# Altium schematic sheet 6_SX1262.SchDoc(6_SX1262)
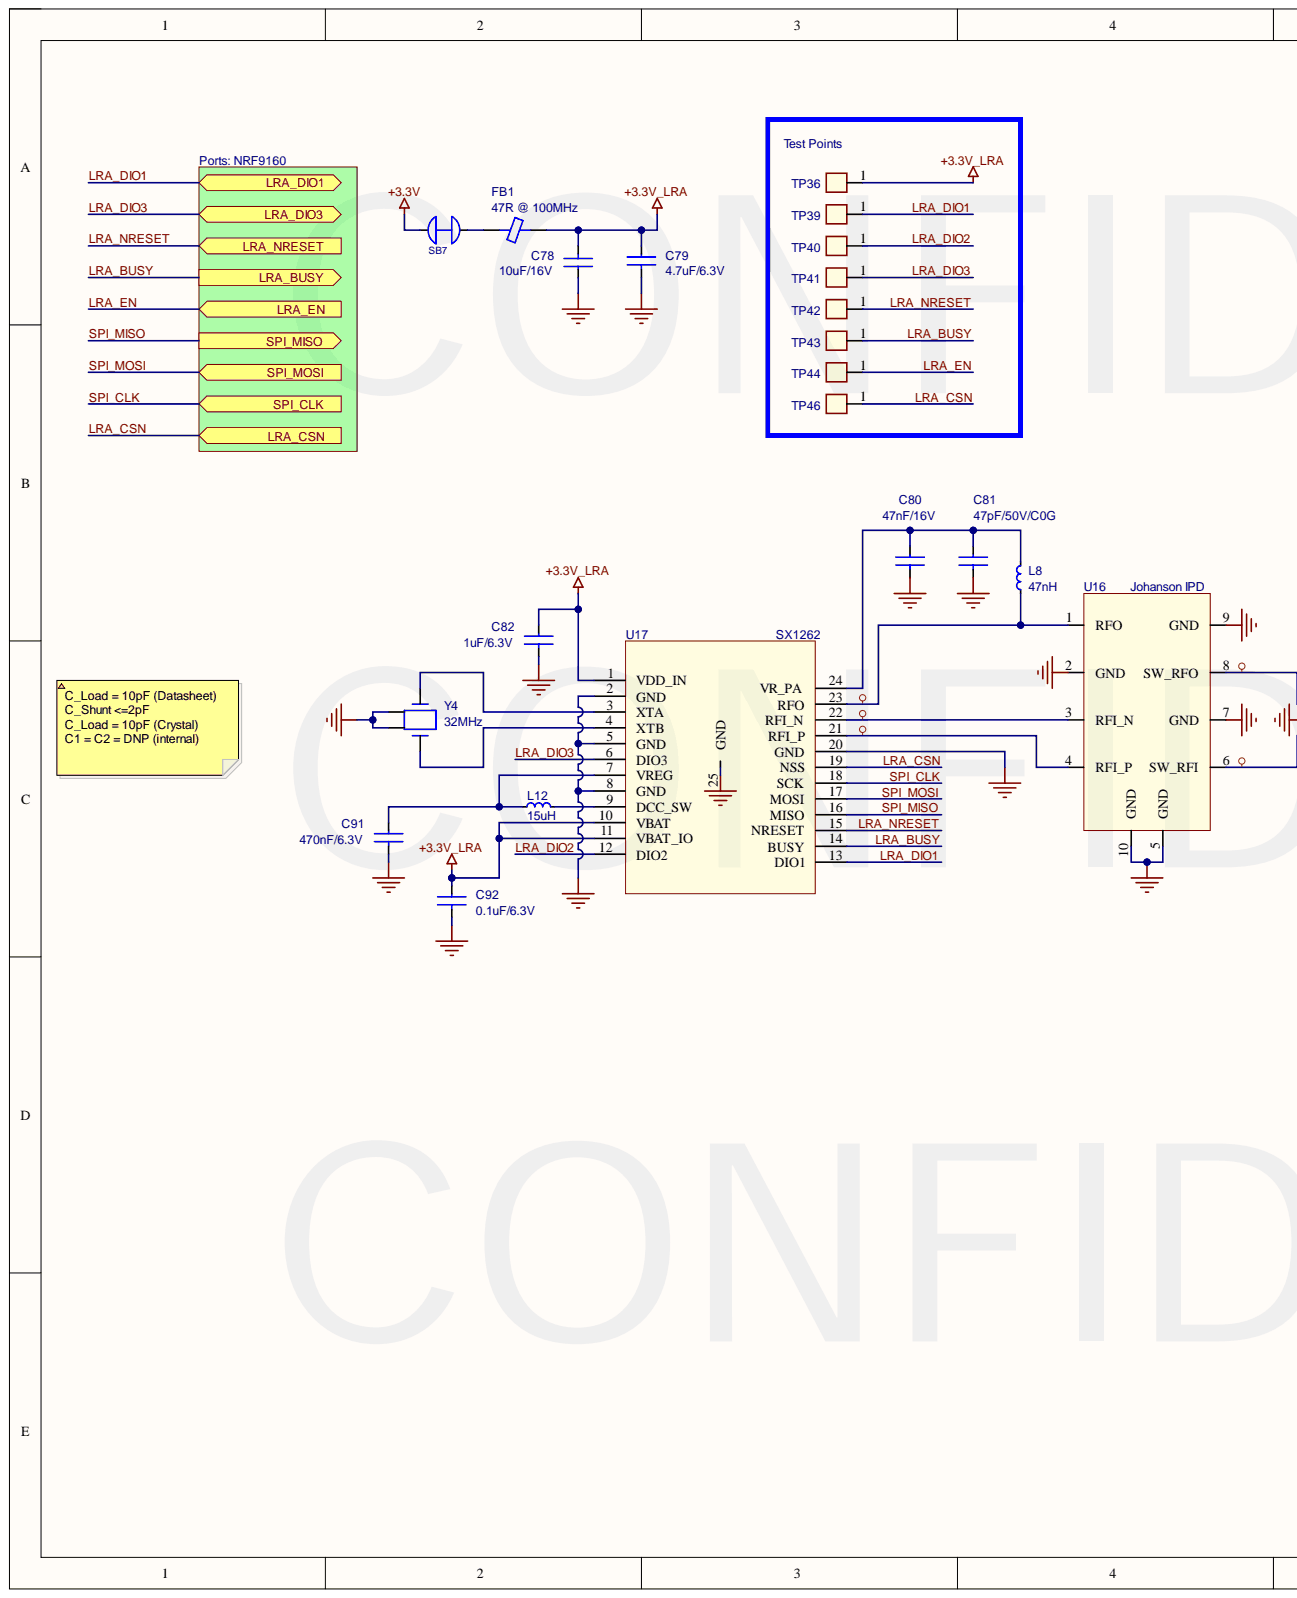
[diagram p0: 6_SX1262.SchDoc, left]
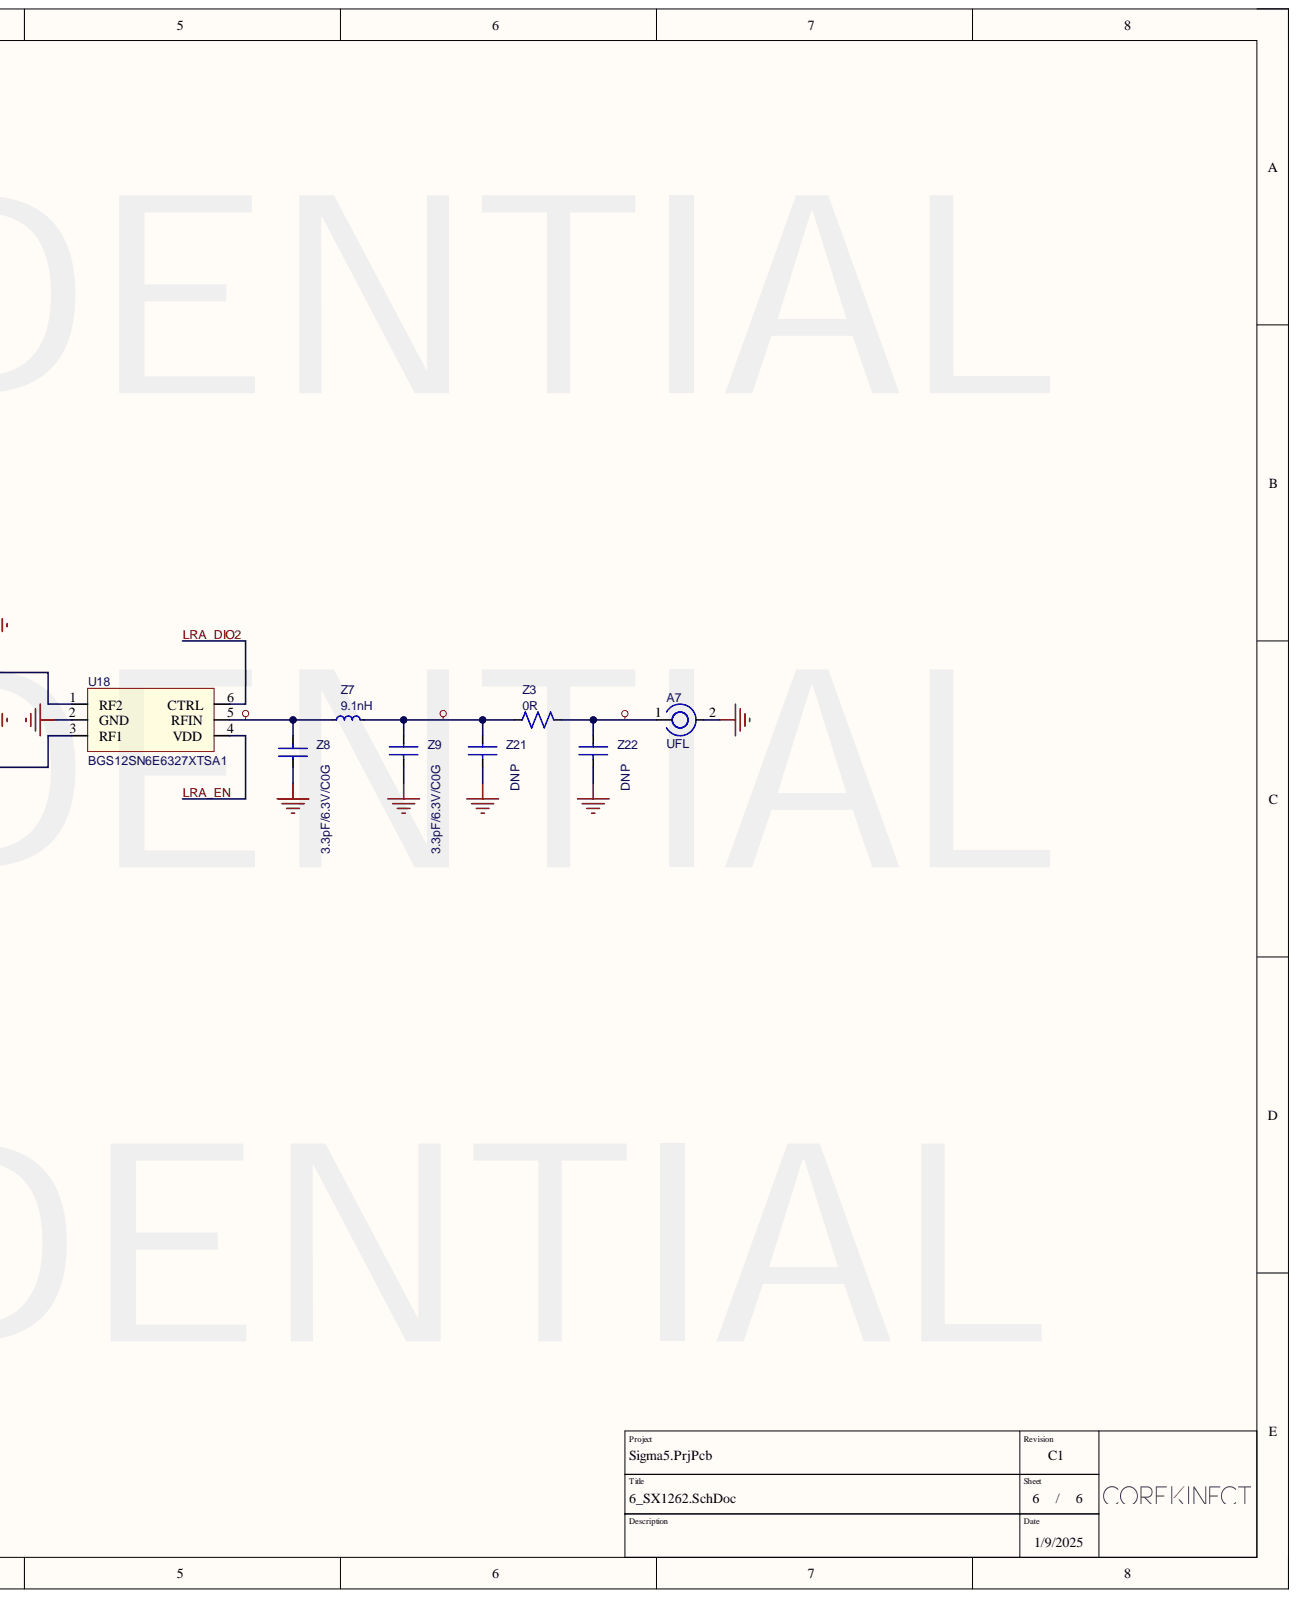
[diagram p1: 6_SX1262.SchDoc, right]

## Parts
A7  UFL  [U.FL-INLINE]
C78  10uF/16V  [CAP_0603]
C79  4.7uF/6.3V  [CAP_0603]
C80  47nF/16V  [CAP_0201]
C81  47pF/50V/C0G  [CAP_0201]
C82  1uF/6.3V  [CAP_0402]
C91  470nF/6.3V  [CAP_0402]
C92  0.1uF/6.3V  [CAP_0402]
FB1  47R @ 100MHz  [IND_0603]
L8  47nH  [CAP_0402]
L12  15uH  [IND_0805]
SB7  SOLDER BRIDGE CLOSED  [RES_0402]  (value not drawn)
TP36  TP  [SMT_TP35_SM]
TP39  TP  [SMT_TP35_SM]
TP40  TP  [SMT_TP35_SM]
TP41  TP  [SMT_TP35_SM]
TP42  TP  [SMT_TP35_SM]
TP43  TP  [SMT_TP35_SM]
TP44  TP  [SMT_TP35_SM]
TP46  TP  [SMT_TP35_SM]
U16  Johanson IPD  [IPD_0900FM15D0039]
U17  SX1262  [SX1262]
U18  BGS12SN6E6327XTSA1  [FP-PG-TSNP-6-MFG]
Y4  32MHz  [XTL4_2.5X2.0MM_SM]
Z3  0R  [RES_0201]
Z7  9.1nH  [IND_0201]
Z8  3.3pF/6.3V/C0G  [CAP_0201]
Z9  3.3pF/6.3V/C0G  [CAP_0201]
Z21  DNP  [CAP_0201]
Z22  DNP  [CAP_0201]

## Ports
LRA_BUSY, LRA_CSN, LRA_DIO1, LRA_DIO3, LRA_EN, LRA_NRESET, SPI_CLK, SPI_MISO, SPI_MOSI

## Nets
+3.3V: SB7.1
+3.3V_LRA: C78.2 C79.1 C82.1 C92.1 FB1.2 TP36.1 U17.1(VDD_IN) U17.10(VBAT) U17.11(VBAT_IO)
GND: A7.2 C78.1 C79.2 C80.2 C81.2 C82.2 C91.2 C92.2 U16.2(GND) U16.5(GND) U16.7(GND) U16.9(GND) U16.10(GND) U17.2(GND) U17.5(GND) U17.8(GND) U17.20(GND) U17.25 U18.2(GND) Y4.2 Y4.4 Z8.1 Z9.2 Z21.2 Z22.2
LRA_BUSY: TP43.1 U17.14(BUSY) ; port LRA_BUSY
LRA_CSN: TP46.1 U17.19(NSS) ; port LRA_CSN
LRA_DIO1: TP39.1 U17.13(DIO1) ; port LRA_DIO1
LRA_DIO2: TP40.1 U17.12(DIO2) U18.6(CTRL)
LRA_DIO3: TP41.1 U17.6(DIO3) ; port LRA_DIO3
LRA_EN: TP44.1 U18.4(VDD) ; port LRA_EN
LRA_NRESET: TP42.1 U17.15(NRESET) ; port LRA_NRESET
SPI_CLK: U17.18(SCK) ; port SPI_CLK
SPI_MISO: U17.16(MISO) ; port SPI_MISO
SPI_MOSI: U17.17(MOSI) ; port SPI_MOSI
NetA7_1: A7.1 Z3.2 Z22.1
NetC80_1: C80.1 C81.1 L8.2 U17.24(VR_PA)
NetC91_1: C91.1 L12.1 U17.7(VREG)
NetFB1_1: FB1.1 SB7.2
NetL8_1: L8.1 U16.1(RFO) U17.23(RFO)
NetL12_2: L12.2 U17.9(DCC_SW)
NetU16_3: U16.3(RFI_N) U17.22(RFI_N)
NetU16_4: U16.4(RFI_P) U17.21(RFI_P)
NetU16_6: U16.6(SW_RFI) U18.3(RF1)
NetU16_8: U16.8(SW_RFO) U18.1(RF2)
NetU17_3: U17.3(XTA) Y4.3
NetU17_4: U17.4(XTB) Y4.1
NetU18_5: U18.5(RFIN) Z7.1 Z8.2
NetZ3_1: Z3.1 Z7.2 Z9.1 Z21.1

## Library data of the parts
A7 = UFL_INLINE: Component Kind: Standard; Library Name: CoreKinectIntegratedLibrary.IntLib
C78 C79 = CAP_0603: Component Kind: Standard; Package / Case: 0603; Library Name: CoreKinectIntegratedLibrary.IntLib
C80 = CAP_0201: Component Kind: Standard; Package / Case: 0201; Library Name: CoreKinectIntegratedLibrary.IntLib
C81 Z8 Z9 Z21 Z22 = CAP_0201: Notes: LoRa tuning - High Q; Component Kind: Standard; Package / Case: 0201; Library Name: CoreKinectIntegratedLibrary.IntLib
C82 C91 C92 = CAP_0402: Component Kind: Standard; Package / Case: 0402; Library Name: CoreKinectIntegratedLibrary.IntLib
FB1 = FERRITE BEAD: Manufacturer 1: Murata; Manufacturer Part Number 1: BLM18BB470SN1D; Component Kind: Standard; Package / Case: 0603; Library Name: CoreKinectIntegratedLibrary.IntLib
L8 = IND_0402: Notes: LoRa tuning - High Q; Component Kind: Standard; Package / Case: 0402; Library Name: CoreKinectIntegratedLibrary.IntLib
L12 = IND_0805: FIXED IND 15UH 250MA 950 MOHM; Manufacturer 1: TDK Corporation; Manufacturer Part Number 1: MLZ2012M150WT000; Component Kind: Standard; Package / Case: 0805; Library Name: CoreKinectIntegratedLibrary.IntLib
SB7 = MISC-00000B: Short circuit 0402; Manufacturer 1: CoreKinect; Manufacturer Part Number 1: Short-Circuit; Database Table Name: Misc; Component Kind: Net Tie; CPN: MISC-00000B; Library Name: CoreKinectDatabaseLibrary.DbLib
TP36 TP39-TP44 TP46 = CON1_1: PN: SMT_TP35; Component Kind: Standard
U16 = IPD_0900FM15D0039: Johanson IPD 0900FM15D0039 for SX1261/2, LLCC68; Manufacturer 1: Johanson Technology; Component Kind: Standard; Manufacturer Part Number 1: 0900FM15D0039; Library Name: CoreKinectIntegratedLibrary.IntLib
U17 = SX1262: TXRX LORA SUB-GHZ 22DBM 24MLPQ; Manufacturer 1: Semtech; Manufacturer Part Number 1: SX1262IMLTRT; Current - Receiving: 10.1mA; Current - Transmitting: 118mA; Data Rate: 300Kbps; Frequency: 960MHz; Interface: SPI; Sensitivity: -148dBm; Category: RF Receivers, Transceivers; Component Kind: Standard; ComponentLink1Description: http://www.farnell.com/datasheets/2829356.pdf?src-supplier=Farnell; ComponentLink1URL: http://www.farnell.com/datasheets/2829356.pdf?src-supplier=Farnell; ComponentLink2Description: http://www.farnell.com/datasheets/2829356.pdf?src-supplier=Newark; ComponentLink2URL: http://www.farnell.com/datasheets/2829356.pdf?src-supplier=Newark; ComponentLink3Description: https://www.semtech.com/uploads/documents/DS_SX1261-2_V1.1.pdf?src-supplier=Digi-Key; ComponentLink3URL: https://www.semtech.com/uploads/documents/DS_SX1261-2_V1.1.pdf?src-supplier=Digi-Key; Max Operating Temperature: 85°C; Min Operating Temperature: -40°C; Packaging: Cut Tape; Pricing 1: 1=7.56000, 10=6.93000, 25=6.30000, 100=5.67000, 250=5.19752, 500=4.72500, 1000=4.25250 (USD); RoHS: No; Stock 1: 2023; Supplier 1: Digi-Key; Supplier Part Number 1: SX1262IMLTRTCT-ND; Library Name: CoreKinectIntegratedLibrary.IntLib
U18 = BGS12SN6E6327XTSA1: INFINEON - BGS12SN6E6327XTSA1 - Circuit commutateur RF, SPDT, 100 MHz à 6 GHz, TTL, CMOS, 1.8 V à 3.5 V TSNP-6; Impedance: 50R; Insertion Loss: 0.65dB; Operating Supply Voltage: 3.3V; Test Frequency: 6GHz; Category: RF Semiconductors and Devices; Component Kind: Standard; ComponentLink1Description: https://datasheet.ciiva.com/30043/infineon-bgs12sn6-ds-v01-02-en-30043782.pdf?src-supplier=Avnet; ComponentLink1URL: https://datasheet.ciiva.com/30043/infineon-bgs12sn6-ds-v01-02-en-30043782.pdf?src-supplier=Avnet; Halogen Free: Halogen Free; Lead Free: Lead Free; Manufacturer 1: Infineon; Manufacturer Part Number 1: BGS12SN6E6327XTSA1; Max Operating Temperature: 85°C; Min Operating Temperature: -40°C; Mount: Surface Mount; Number of Pins: 6; Packaging: Tape and Reel; Pricing 1: 1=0.36000, 10=0.29300, 25=0.26840, 100=0.19970, 250=0.18096, 500=0.14976, 1000=0.11232, 2500=0.10296, 5000=0.09672 (USD); RoHS: Yes; Stock 1: 3875; Supplier 1: Digi-Key; Supplier Part Number 1: BGS12SN6E6327XTSA1CT-ND; Throw Configuration: SPDT; Library Name: CoreKinectIntegratedLibrary.IntLib
Y4 = JXS22-WA: CRYSTAL 32MHZ 10PF SMD; Manufacturer 1: Jauch; Manufacturer Part Number 1: Q 32,0-JXS22-10-10/10-FU-WA-LF; Component Kind: Standard; RoHS: No; Supplier 1: Digi-Key; Supplier Part Number 1: 1908-1292-1-ND; Library Name: CoreKinectIntegratedLibrary.IntLib
Z3 = RES_0201: Component Kind: Standard; Package / Case: 0201; Library Name: CoreKinectIntegratedLibrary.IntLib
Z7 = IND_0201: Notes: LoRa tuning - High Q; Component Kind: Standard; Package / Case: 0201; Library Name: CoreKinectIntegratedLibrary.IntLib
